# Altium schematic sheet Breathalyzer.SchDoc(Breathalyzer) (continued)

## Library data of the parts
C1-C3 C7-C9 = 08056C106KAT2A: CAP CER 10UF 6.3V X7R 0805; Manufacturer: Kyocera AVX; Tolerance: 10%; Width: 0.049inch; Voltage Rating (DC): 6.3V; Voltage Rating: 6.3V; Voltage: 6.3V; Thickness: 0.055inch; Termination: SMD/SMT; Supplier Part Number 1: 478-5322-6-ND; Supplier 1: Digi-Key; Stock 1: 0; REACH SVHC: No SVHC; Radiation Hardening: No; Pricing 1: 1=0.83, 10=0.614, 100=0.4209, 500=0.33322, 1000=0.2806 (USD); Packaging: Tape and Reel; Package Quantity: 1; Number of Pins: 2; Mount: PCB,Surface Mount; Min Operating Temperature: -55°C; Max Operating Temperature: 125°C; Manufacturer Part Number: 08056C106KAT2A; Length: 2.01mm; Lead Free: Lead Free; Height: 1.52mm; Dielectric: X7R; Depth: 1.25mm; Contact Plating: Matte,Tin; ComponentLink7URL: http://datasheets.avx.com/X7RDielectric.pdf; ComponentLink7Description: http://datasheets.avx.com/X7RDielectric.pdf; ComponentLink6URL: http://catalogs.avx.com/SurfaceMount.pdf; ComponentLink6Description: http://catalogs.avx.com/SurfaceMount.pdf; ComponentLink5URL: http://www.avx.com/docs/catalogs/cx7r.pdf; ComponentLink5Description: http://www.avx.com/docs/catalogs/cx7r.pdf; ComponentLink4URL: https://www.verical.com/server-webapp/html/getDatasheet?partID=149687; ComponentLink4Description: https://www.verical.com/server-webapp/html/getDatasheet?partID=149687; ComponentLink3URL: http://www.mouser.com/ds/2/40/X7RDielectric-777024.pdf; ComponentLink3Description: http://www.mouser.com/ds/2/40/X7RDielectric-777024.pdf; ComponentLink2URL: http://avx.com/docs/Catalogs/cx7r.pdf; ComponentLink2Description: http://avx.com/docs/Catalogs/cx7r.pdf; ComponentLink1URL: http://www.farnell.com/datasheets/2095836.pdf; ComponentLink1Description: http://www.farnell.com/datasheets/2095836.pdf; Component Kind: Standard; Case Code (Metric): 2012; Case Code (Imperial): 0805; Case/Package: 0805; Capacitance: 10uF; Library Name: Breathalyzer.SchLib
C4 C10 C14 C16 C20 C21 = GRM188R71E104KA01D: CAP CER 0.1UF 25V X7R 0603; Manufacturer: Murata; Tolerance: 10%; Material: Ceramic; Capacitance: 100nF; Width: 0.031inch; Weight: 7.1E-05oz; Voltage Rating: 25V; Voltage: 25V; Thickness: 0.035inch; Termination: SMD/SMT; Supplier Part Number 1: 490-1524-6-ND; Supplier 1: Digi-Key; Stock 1: 4000; REACH SVHC: Unknown; Radiation Hardening: No; Pricing 1: 1=0.13 (USD); Packaging: Tape and Reel; Package Quantity: 1; Number of Pins: 2; Mount: Surface Mount; Min Operating Temperature: -55°C; Max Operating Temperature: 125°C; Manufacturer Part Number: GRM188R71E104KA01D; Length: 1.6mm; Lead Free: Lead Free; Height: 0.8mm; Dielectric: X7R; Depth: 0.8mm; ComponentLink6URL: https://produktinfo.conrad.com/datenblaetter/450000-474999/451555-da-01-en-VS_KONDENSATOR_0603.pdf; ComponentLink6Description: https://produktinfo.conrad.com/datenblaetter/450000-474999/451555-da-01-en-VS_KONDENSATOR_0603.pdf; ComponentLink5URL: https://www.verical.com/server-webapp/html/getDatasheet?partID=44549; ComponentLink5Description: https://www.verical.com/server-webapp/html/getDatasheet?partID=44549; ComponentLink4URL: http://www.jameco.com/Jameco/Products/ProdDS/1857495.pdf; ComponentLink4Description: http://www.jameco.com/Jameco/Products/ProdDS/1857495.pdf; ComponentLink3URL: http://www.farnell.com/datasheets/1747454.pdf; ComponentLink3Description: http://www.farnell.com/datasheets/1747454.pdf; ComponentLink2URL: http://psearch.en.murata.com/capacitor/product/GRM188R71E103KA01%23.pdf; ComponentLink2Description: http://psearch.en.murata.com/capacitor/product/GRM188R71E103KA01%23.pdf; ComponentLink1URL: https://www.murata.com/~/media/webrenewal/support/library/catalog/products/capacitor/mlcc/c02e.ashx?la=en-us; ComponentLink1Description: https://www.murata.com/~/media/webrenewal/support/library/catalog/products/capacitor/mlcc/c02e.ashx?la=en-us; Component Kind: Standard; Case Code (Metric): 1608; Case Code (Imperial): 0603; Case/Package: 0603; Library Name: Breathalyzer.SchLib
  C4 C10 C20 C21: Voltage Rating (DC): 25V
C5 C11 C23 = GRM188R61A105KA61J: Multilayer Ceramic Capacitors MLCC - SMD/SMT 0603 1uF 10volts X5R 10%; Manufacturer: Murata; Voltage Rating (DC): 10V; Width: 0.031inch; Voltage Rating: 10V; Thickness: 0.035inch; Termination: SMD/SMT; Supplier Part Number 1: 490-6408-1-ND; Supplier 1: Digi-Key; Stock 1: 100000; REACH SVHC: No SVHC; Radiation Hardening: No; Packaging: Tape and Reel; Package Quantity: 1; Mount: Surface Mount; Min Operating Temperature: -55°C; Max Operating Temperature: 85°C; Manufacturer Part Number: GRM188R61A105KA61J; Length: 1.6mm; Height: 0.8mm; Dielectric: X5R; Depth: 0.8mm; ComponentLink1URL: https://www.murata.com/~/media/webrenewal/support/library/catalog/products/capacitor/mlcc/c02e.ashx?la=en-us; ComponentLink1Description: https://www.murata.com/~/media/webrenewal/support/library/catalog/products/capacitor/mlcc/c02e.ashx?la=en-us; Component Kind: Standard; Case Code (Metric): 1608; Case Code (Imperial): 0603; Case/Package: 0603; Library Name: Breathalyzer.SchLib
  C5 C11: Tolerance: 10%
C6 = CC0805KKX7R8BB474: CAP CER 0.47UF 25V X7R 0805; Manufacturer: Yageo; Tolerance: 10%; Insulation Resistance: 10GR; Dissipation Factor: 5%; Capacitance: 470nF; Width: 0.049inch; Voltage Rating (DC): 25V; Voltage Rating: 25V; Voltage: 25V; Thickness: 0.049inch; Termination: SMD/SMT; Supplier Part Number 1: 311-1364-6-ND; Supplier 1: Digi-Key; Stock 1: 0; REACH SVHC: No SVHC; Radiation Hardening: No; Pricing 1: 1=0.29, 10=0.197, 100=0.0997, 500=0.06824, 1000=0.06037 (USD); Packaging: Tape and Reel; Package Quantity: 3000; Number of Pins: 2; Mount: Surface Mount; Min Operating Temperature: -55°C; Max Operating Temperature: 125°C; Manufacturer Part Number: CC0805KKX7R8BB474; Length: 2mm; Lead Free: Lead Free; Height: 1.25mm; Halogen Free: Halogen Free; Dielectric: X7R; Depth: 1.25mm; Composition: Ceramic; ComponentLink5URL: http://www.mouser.com/ds/2/447/Yageo_UPY-GP_NP0_16V-to-50V_9-358835.pdf; ComponentLink5Description: http://www.mouser.com/ds/2/447/Yageo_UPY-GP_NP0_16V-to-50V_9-358835.pdf; ComponentLink4URL: https://www.verical.com/server-webapp/html/getDatasheet?partID=315216; ComponentLink4Description: https://www.verical.com/server-webapp/html/getDatasheet?partID=315216; ComponentLink3URL: http://www.yageo.com/documents/recent/UPY-GPHC_X7R_6.3V-to-50V_18.pdf; ComponentLink3Description: http://www.yageo.com/documents/recent/UPY-GPHC_X7R_6.3V-to-50V_18.pdf; ComponentLink2URL: http://www.farnell.com/datasheets/1489800.pdf; ComponentLink2Description: http://www.farnell.com/datasheets/1489800.pdf; ComponentLink1URL: http://documents.rs-components.com/techlib://itc_doclist_GB.htm?stockno=6963572; ComponentLink1Description: http://documents.rs-components.com/techlib://itc_doclist_GB.htm?stockno=6963572; Component Kind: Standard; Case Code (Metric): 2012; Case Code (Imperial): 0805; Case/Package: 0805; Library Name: Breathalyzer.SchLib
C12 C22 = GRM31CR60J107ME39K: Multilayer Ceramic Capacitors MLCC - SMD/SMT 1206 100uF 6.3volt X5R +/-20%; Manufacturer: Murata; Tolerance: 20%; Width: 0.063inch; Voltage Rating (DC): 6.3V; Voltage Rating: 6.3V; Voltage: 6.3V; Thickness: 0.071inch; Termination: SMD/SMT; Supplier Part Number 1: GRM31CR60J107ME39K-ND; Supplier 1: Digi-Key; Stock 1: 0; REACH SVHC: No SVHC; Radiation Hardening: No; Packaging: Tape and Reel; Package Quantity: 1; Mount: Surface Mount; Min Operating Temperature: -55°C; Max Operating Temperature: 85°C; Manufacturer Part Number: GRM31CR60J107ME39K; Length: 3.2mm; Lead Free: Lead Free; Height: 1.6mm; Dielectric: X5R; Depth: 1.6mm; ComponentLink2URL: https://www.murata.com/~/media/webrenewal/support/library/catalog/products/capacitor/mlcc/c02e.ashx?la=en-us; ComponentLink2Description: https://www.murata.com/~/media/webrenewal/support/library/catalog/products/capacitor/mlcc/c02e.ashx?la=en-us; ComponentLink1URL: http://www.mouser.com/ds/2/281/GRM31A7U2H471JW31-01-1064911.pdf; ComponentLink1Description: http://www.mouser.com/ds/2/281/GRM31A7U2H471JW31-01-1064911.pdf; Component Kind: Standard; Case Code (Metric): 3216; Case Code (Imperial): 1206; Case/Package: 1206; Library Name: Breathalyzer.SchLib
C13 C15 = TMK316BJ106ML-T: CAP CER 10UF 25V X5R 1206; Manufacturer: Taiyo Yuden; Tolerance: 10%; Width: 1.6mm; Voltage Rating (DC): 25V; Voltage Rating: 25V; Voltage: 25V; Thickness: 0.071inch; Termination: SMD/SMT; Supplier Part Number 1: 587-2259-6-ND; Supplier 1: Digi-Key; Stock 1: 0; REACH SVHC: Unknown; Radiation Hardening: No; Pricing 1: 1=0.34, 10=0.236, 100=0.1339, 500=0.09454, 1000=0.08271 (USD); Packaging: Tape and Reel; Package Quantity: 1; Number of Pins: 2; Mount: Surface Mount; Min Operating Temperature: -55°C; Max Operating Temperature: 85°C; Manufacturer Part Number: TMK316BJ106ML-T; Length: 3.2mm; Lead Free: Lead Free; Height: 1.6mm; Halogen Free: Halogen Free; Dielectric: X5R; Depth: 1.6mm; Contact Plating: Nickel; Composition: Ceramic; ComponentLink3URL: http://www.farnell.com/datasheets/746258.pdf; ComponentLink3Description: http://www.farnell.com/datasheets/746258.pdf; ComponentLink2URL: http://www.farnell.com/datasheets/1481447.pdf; ComponentLink2Description: http://www.farnell.com/datasheets/1481447.pdf; ComponentLink1URL: https://www.yuden.co.jp/productdata/catalog/mlcc01_e.pdf; ComponentLink1Description: https://www.yuden.co.jp/productdata/catalog/mlcc01_e.pdf; Component Kind: Standard; Case Code (Metric): 3216; Case Code (Imperial): 1206; Case/Package: 1206; Library Name: Breathalyzer.SchLib
C17-C19 C24 = UMK107SD102KA-T: CAP CER 1000PF 50V 0603; Manufacturer: Taiyo Yuden; Tolerance: 10%; Dielectric Material: Ceramic; Width: 0.8mm; Voltage Rating: 50V; Voltage: 50V; Thickness: 0.035inch; Termination: SMD/SMT; Supplier Part Number 1: 587-1070-6-ND; Supplier 1: Digi-Key; Stock 1: 4000; Radiation Hardening: No; Pricing 1: 1=0.36, 10=0.252, 100=0.1509, 500=0.1132, 1000=0.09559 (USD); Packaging: Tape and Reel; Package Quantity: 1; Number of Pins: 2; Mount: Surface Mount; Min Operating Temperature: -55°C; Max Operating Temperature: 125°C; Manufacturer Part Number: UMK107SD102KA-T; Length: 1.6mm; Lead Free: Lead Free; Height: 0.8mm; Halogen Free: Halogen Free; Depth: 0.8mm; ComponentLink3URL: http://ds.yuden.co.jp/TYCOMPAS/ut/detail.do?productNo=UMK107SD102KA-T&fileName=UMK107SD102KA-T_SS&mode=specSheetDownload; ComponentLink3Description: http://ds.yuden.co.jp/TYCOMPAS/ut/detail.do?productNo=UMK107SD102KA-T&fileName=UMK107SD102KA-T_SS&mode=specSheetDownload; ComponentLink2URL: http://documents.rs-components.com/techlib://itc_doclist_GB.htm?stockno=7587377; ComponentLink2Description: http://documents.rs-components.com/techlib://itc_doclist_GB.htm?stockno=7587377; ComponentLink1URL: http://www.yuden.co.jp/productdata/catalog/en/mlcc07_e.pdf; ComponentLink1Description: http://www.yuden.co.jp/productdata/catalog/en/mlcc07_e.pdf; Component Kind: Standard; Case Code (Metric): 1608; Case Code (Imperial): 0603; Case/Package: 0603; Library Name: Breathalyzer.SchLib
  C24: Voltage Rating (DC): 50V; Capacitance: 1nF
D1 = LTST-C193TBKT-5A: Standard LEDs - SMD Blue 470nm 28mcd 5mA; Manufacturer: Vishay Lite-On; Viewing Angle: 130°; Reverse Voltage: 5V; Power Dissipation: 76mW; Number of LEDs: 1; Luminous Intensity: 15mcd; Lens Transparency: Clear; Lens Style: Clear,Colorless,Diffused,Rectangular; Lens Colour: Clear,Colorless; Forward Voltage: 2.8V; Forward Current: 20mA; Dominant Wavelength: 475nm; Width: 0.8mm; Test Current: 5mA; Supplier Part Number 1: 859-LTSTC193TBKT5A; Supplier 1: Mouser; Stock 1: 100531; Radiation Hardening: No; Pricing 1: 1=0.43, 10=0.23, 50=0.23, 100=0.108, 1000=0.078, 10000=0.055 (USD); Packaging: Tape and Reel; Package Quantity: 1; Number of Pins: 2; Number of Elements: 1; Mount: Surface Mount; Min Operating Temperature: -20°C; Max Operating Temperature: 80°C; Manufacturer Part Number: LTST-C193TBKT-5A; Length: 1.6mm; Lead Free: Lead Free; Illumination Colour: Blue; Height: 0.35mm; Depth: 0.8mm; ComponentLink5URL: http://www1.futureelectronics.com/doc/LITE-ON/LTST-C193TBKT-5A.pdf; ComponentLink5Description: http://www1.futureelectronics.com/doc/LITE-ON/LTST-C193TBKT-5A.pdf; ComponentLink4URL: http://uk.rs-online.com/webdocs/0dc0/0900766b80dc0554.pdf; ComponentLink4Description: http://uk.rs-online.com/webdocs/0dc0/0900766b80dc0554.pdf; ComponentLink3URL: https://www.verical.com/server-webapp/html/getDatasheet?partID=293056; ComponentLink3Description: https://www.verical.com/server-webapp/html/getDatasheet?partID=293056; ComponentLink2URL: https://media.digikey.com/pdf/Data%20Sheets/Lite-On%20PDFs/LTST-C193TBKT-5A.pdf; ComponentLink2Description: https://media.digikey.com/pdf/Data%20Sheets/Lite-On%20PDFs/LTST-C193TBKT-5A.pdf; ComponentLink1URL: http://www.mouser.com/ds/2/239/Lite-On-LTST-C193TBKT-5A-187386.pdf; ComponentLink1Description: http://www.mouser.com/ds/2/239/Lite-On-LTST-C193TBKT-5A-187386.pdf; Component Kind: Standard; Colour: Blue; Case Code (Metric): 1608; Case Code (Imperial): 0603; Case/Package: 0603; Library Name: Breathalyzer.SchLib
J1 = 1042P: Cylindrical Battery Contacts, Clips, Holders & Springs SMT Polarized Holder for 18650 Battery; Manufacturer: Keystone Electronics; Number of Cells: 1; Termination: SMD/SMT; Supplier Part Number 1: 36-1042P-ND; Supplier 1: Digi-Key; Stock 1: 3395; Pricing 1: 1=2.47, 10=2.107, 50=1.892, 100=1.806, 250=1.634, 1000=1.539, 2500=1.29, 5000=1.153 (USD); Packaging: Tray; Package Quantity: 200; Mount: PCB,Surface Mount; Min Operating Temperature: -50°C; Max Operating Temperature: 145°C; Manufacturer Part Number: 1042P; Lead Free: Lead Free; ComponentLink2URL: https://www.mouser.com/datasheet/2/215/042P-744096.pdf; ComponentLink2Description: Datasheet; ComponentLink1URL: https://www.mouser.com/ProductDetail/Keystone-Electronics/1042P?qs=sGAEpiMZZMtqO%252bWUGLBzeAoSIwG%2fyfJm2NzM8DAiwxE%3d; ComponentLink1Description: Mouser; Component Kind: Standard; Library Name: Breathalyzer.SchLib
J2 = I2C Testing: 1x4 Header for I2C Testing; Component Kind: Standard; Library Name: Breathalyzer.SchLib
J3 = 12401598E4#2A: USB - C USB 3.1, Superspeed+ Receptacle Connector 24 Position Surface Mount, Right Angle, Horizontal; Manufacturer: Amphenol Commercial; Number of Contacts: 24; Supplier Part Number 1: 523-12401598E4#2A; Supplier 1: Mouser; Stock 1: 1682; Pricing 1: 1=1.75, 10=1.58, 50=1.42, 100=1.36, 1000=0.901, 10000=0.898 (USD); Packaging: Tape and Reel; Mount: Horizontal,Surface Mount; Manufacturer Part Number: 12401598E4#2A; Gender: Receptacle; Connector Type: USB; ComponentLink1URL: https://www.amphenol-icc.com/media/wysiwyg/files/drawing/12401598xxx2a.pdf; ComponentLink1Description: Drawing; Component Kind: Standard; Library Name: Breathalyzer.SchLib
L1 L2 = VLF4012AT-2R2M1R5: FIXED IND 2.2UH 1.5A 87 MOHM SMD; Manufacturer: TDK; Tolerance: 20%; Test Frequency: 100kHz; Shielding: Shielded; DC Resistance (DCR): 87mR; Core Material: Ferrite; Width: 0.138inch; Termination: SMD/SMT; Supplier Part Number 1: 445-3233-1-ND; Supplier 1: Digi-Key; Stock 1: 94427; RMS Current (Irms): 1.5A; REACH SVHC: No SVHC; Radiation Hardening: No; Pricing 1: 1=0.83, 10=0.725, 100=0.5175, 500=0.4347 (USD); Packaging: Tape and Reel; Package Quantity: 1000; Number of Pins: 2; Mount: Surface Mount; Min Operating Temperature: -40°C; Military Standard: Not; Max Operating Temperature: 105°C; Max DC Current: 1.5A; Manufacturer Part Number: VLF4012AT-2R2M1R5; Length: 0.146inch; Lead Free: Lead Free; Inductance Tolerance: 20%; Inductance: 2.2uh; Height - Seated (Max): 0.047inch; Height: 1.2mm; Depth: 3.8mm; DC Current: 1.5A; Current Rating: 1.5A; Composition: Wirewound; ComponentLink2URL: http://www.onlinecomponents.com/productFiles/mf-TDK/e531_vlf4012a.pdf; ComponentLink2Description: http://www.onlinecomponents.com/productFiles/mf-TDK/e531_vlf4012a.pdf; ComponentLink1URL: http://www.farnell.com/datasheets/333336.pdf; ComponentLink1Description: http://www.farnell.com/datasheets/333336.pdf; Component Kind: Standard; Case/Package: Nonstandard; Library Name: Breathalyzer.SchLib
Q1 Q2 = MMSS8050-L-TP: TRANS NPN 25V 1.5A SOT-23; Manufacturer: MCC; Transition Frequency: 100MHz; Max Power Dissipation: 625mW; hFE Min: 40; Emitter Base Voltage (VEBO): 5V; Element Configuration: Single; Collector Emitter Voltage (VCEO): 500mV; Collector Base Voltage (VCBO): 40V; Supplier Part Number 1: MMSS8050-L-TPMSDKR-ND; Supplier 1: Digi-Key; Stock 1: 28424; Pricing 1: 1=0.21, 10=0.19, 25=0.1728, 100=0.1246, 250=0.07336, 500=0.0609, 1000=0.04152 (USD); Polarity: NPN; Packaging: Tape and Reel; Package Quantity: 3000; Mount: Surface Mount; Min Operating Temperature: -55°C; Max Operating Temperature: 150°C; Max Collector Current: 1.5A; Max Breakdown Voltage: 25V; Manufacturer Part Number: MMSS8050-L-TP; Lead Free: Lead Free; Gain Bandwidth Product: 100MHz; ComponentLink2URL: http://www.mouser.com/ds/2/258/MMSS8050(SOT-23)-276387.pdf; ComponentLink2Description: http://www.mouser.com/ds/2/258/MMSS8050(SOT-23)-276387.pdf; ComponentLink1URL: http://www.mccsemi.com/up_pdf/MMSS8050(SOT-23).pdf; ComponentLink1Description: http://www.mccsemi.com/up_pdf/MMSS8050(SOT-23).pdf; Component Kind: Standard; Collector Emitter Saturation Voltage: 500mV; Collector Emitter Breakdown Voltage: 25V; Case/Package: TO-236-3; Library Name: Breathalyzer.SchLib
Q3 Q4 = DMP2045U-7: P-Channel MOSFET 045R at VGS=-4.5V ID = -4.3A; Manufacturer: Diodes; Supplier Part Number 1: 621-DMP2045U-7; Supplier 1: Mouser; Stock 1: 0; Pricing 1: 1=0.45, 10=0.289, 50=0.289, 100=0.124, 1000=0.095, 10000=0.064 (USD); Manufacturer Part Number: DMP2045U-7; ComponentLink1URL: https://www.diodes.com/assets/Datasheets/DMP2045U.pdf; ComponentLink1Description: Datasheet; Component Kind: Standard; Library Name: Breathalyzer.SchLib
R1 R3 R5 R6 R14-R19 R26 = RC0805FR-0710KL: RES SMD 10K OHM 1% 1/8W 0805; Manufacturer: Yageo; Voltage Rating: 150V; Temperature Coefficient: 100ppm/°C; Resistance: 10kR; Power Rating: 125mW; Width: 0.049inch; Termination: SMD/SMT; Supplier Part Number 1: 311-10.0KCRDKR-ND; Supplier 1: Digi-Key; Stock 1: 50767607; REACH SVHC: Unknown; Pricing 1: 1=0.1, 10=0.046, 100=0.0186, 1000=0.00835, 2500=0.00725 (USD); Packaging: Tape and Reel; Package Quantity: 1; Number of Terminations: 2; Min Operating Temperature: -55°C; Military Standard: Not; Max Power Dissipation: 125mW; Max Operating Temperature: 155°C; Manufacturer Part Number: RC0805FR-0710KL; Length: 2mm; Lead Free: Lead Free; Height: 0.024inch; Features: Moisture Resistant; Depth: 1.25mm; Composition: Thick Film; ComponentLink3URL: http://www.yageo.ru/pdf/RC0805.pdf; ComponentLink3Description: http://www.yageo.ru/pdf/RC0805.pdf; ComponentLink2URL: http://www.farnell.com/datasheets/444164.pdf; ComponentLink2Description: http://www.farnell.com/datasheets/444164.pdf; ComponentLink1URL: http://www.yageo.com/documents/recent/PYu-RC_Group_51_RoHS_L_9.pdf; ComponentLink1Description: http://www.yageo.com/documents/recent/PYu-RC_Group_51_RoHS_L_9.pdf; Component Kind: Standard; Case Code (Metric): 2012; Case Code (Imperial): 0805; Case/Package: 0805; Library Name: Breathalyzer.SchLib
  R1 R15 R16: Tolerance: 1%
R2 R10 = RC0805JR-07100RL: RES, THICK FILM, 100R, 5%, 0.125W, 0805; Manufacturer: Yageo; Voltage Rating: 150V; Temperature Coefficient: 100ppm/°C; Power Rating: 125mW; Tolerance: 5%; Termination: SMD/SMT; Supplier Part Number 1: 603-RC0805JR-07100RL; Supplier 1: Mouser; Stock 1: 497207; Resistance: 100R; REACH SVHC: Unknown; Radiation Hardening: No; Pricing 1: 1=0.11, 10=0.026, 50=0.026, 100=0.009, 1000=0.007, 10000=0.004 (USD); Packaging: Tape and Reel; Min Operating Temperature: -55°C; Max Operating Temperature: 155°C; Manufacturer Part Number: RC0805JR-07100RL; Lead Free: Lead Free; Contact Plating: Lead,Matte,Tin; Composition: Thick Film; ComponentLink4URL: http://www.yageo.ru/pdf/RC0805.pdf; ComponentLink4Description: http://www.yageo.ru/pdf/RC0805.pdf; ComponentLink3URL: http://www.farnell.com/datasheets/442941.pdf; ComponentLink3Description: http://www.farnell.com/datasheets/442941.pdf; ComponentLink2URL: http://www.farnell.com/datasheets/2167052.pdf; ComponentLink2Description: http://www.farnell.com/datasheets/2167052.pdf; ComponentLink1URL: http://www.farnell.com/datasheets/444164.pdf; ComponentLink1Description: http://www.farnell.com/datasheets/444164.pdf; Component Kind: Standard; Case Code (Metric): 2012; Case Code (Imperial): 0805; Case/Package: 0805; Library Name: Breathalyzer.SchLib
R4 R23 = RC0603FR-07100KL: YAGEO         RC0603FR-07100KL             RES, THICK FILM, 100K, 1%, 0.1W, 0603; Working Voltage: 75V; Voltage Rating (DC): 50V; Temperature Coefficient: 100ppm/°C; Resistance: 100kR; Power Rating: 100mW; Width: 0.8mm; Voltage Rating: 50V; Tolerance: 1%; Termination: SMD/SMT; Supplier Part Number 1: 603-RC0603FR-07100KL; Supplier 1: Mouser; Stock 1: 667937; REACH SVHC: No SVHC; Pricing 1: 1=0.1, 10=0.018, 50=0.018, 100=0.006, 1000=0.005, 10000=0.003 (USD); Packaging: Tape and Reel; Number of Terminations: 2; Number of Pins: 2; Min Operating Temperature: -55°C; Military Standard: Not; Max Operating Temperature: 155°C; Manufacturer Part Number: RC0603FR-07100KL; Manufacturer: Yageo; Length: 1.6mm; Lead Free: Lead Free; Height: 0.45mm; Depth: 0.8mm; Composition: Thick Film; ComponentLink5URL: http://www.farnell.com/datasheets/443971.pdf; ComponentLink5Description: http://www.farnell.com/datasheets/443971.pdf; ComponentLink4URL: http://documents.rs-components.com/techlib://itc_doclist_GB.htm?stockno=5048940; ComponentLink4Description: http://documents.rs-components.com/techlib://itc_doclist_GB.htm?stockno=5048940; ComponentLink3URL: http://www.farnell.com/datasheets/1738695.pdf; ComponentLink3Description: http://www.farnell.com/datasheets/1738695.pdf; ComponentLink2URL: http://www.farnell.com/datasheets/1868787.pdf; ComponentLink2Description: http://www.farnell.com/datasheets/1868787.pdf; ComponentLink1URL: http://www.farnell.com/datasheets/2167052.pdf; ComponentLink1Description: http://www.farnell.com/datasheets/2167052.pdf; Component Kind: Standard; Case Code (Metric): 1608; Case Code (Imperial): 0603; Case/Package: 0603; Library Name: Breathalyzer.SchLib
R7 = CRCW080518K0FKEA: RES SMD 18K OHM 1% 1/8W 0805; Manufacturer: Vishay; Working Voltage: 150V; Temperature Coefficient: 100ppm/°C; Resistance: 18kR; Power Rating: 125mW; Width: 0.049inch; Weight: 0.000194oz; Voltage Rating (DC): 150V; Voltage Rating: 150V; Tolerance: 1%; Termination: SMD/SMT; Supplier Part Number 1: 541-18.0KCDKR-ND; Supplier 1: Digi-Key; Stock 1: 0; REACH SVHC: Unknown; Radiation Hardening: No; Pricing 1: 1=0.1, 10=0.069, 100=0.028, 1000=0.01256, 2500=0.0109 (USD); Packaging: Tape and Reel; Package Quantity: 10; Number of Terminations: 2; Number of Pins: 2; Min Operating Temperature: -55°C; Military Standard: Not; Max Power Dissipation: 125mW; Max Operating Temperature: 155°C; Manufacturer Part Number: CRCW080518K0FKEA; Length: 2mm; Lead Free: Lead Free; Height: 0.45mm; Features: Automotive AEC-Q200; Depth: 1.25mm; Contact Plating: Tin; Composition: Thick Film; ComponentLink6URL: http://www.mouser.com/ds/2/427/dcrcwe3-109170.pdf; ComponentLink6Description: http://www.mouser.com/ds/2/427/dcrcwe3-109170.pdf; ComponentLink5URL: http://uk.rs-online.com/webdocs/0d98/0900766b80d98b26.pdf; ComponentLink5Description: http://uk.rs-online.com/webdocs/0d98/0900766b80d98b26.pdf; ComponentLink4URL: http://www.alliedelec.com/m/d/0c934d6939ccefa68dbe0b96d821c8cf.pdf; ComponentLink4Description: http://www.alliedelec.com/m/d/0c934d6939ccefa68dbe0b96d821c8cf.pdf; ComponentLink3URL: http://www.farnell.com/datasheets/2046716.pdf; ComponentLink3Description: http://www.farnell.com/datasheets/2046716.pdf; ComponentLink2URL: https://www.distrelec.biz/Web/Downloads/a_/en/flD-CRCW-e3_data_en.pdf?mime=application%2Fpdf; ComponentLink2Description: https://www.distrelec.biz/Web/Downloads/a_/en/flD-CRCW-e3_data_en.pdf?mime=application%2Fpdf; ComponentLink1URL: http://www.vishay.com/docs/20035/dcrcwe3.pdf; ComponentLink1Description: http://www.vishay.com/docs/20035/dcrcwe3.pdf; Component Kind: Standard; Case Code (Metric): 2012; Case Code (Imperial): 0805; Case/Package: 0805; Library Name: Breathalyzer.SchLib
R8 = CRCW06031K10FKEA: RES SMD 1.1K OHM 1% 1/10W 0603; Manufacturer: Vishay; Voltage Rating (DC): 75V; Temperature Coefficient: 100ppm/°C; Resistance: 1.1kR; Power Rating: 100mW; Width: 0.033inch; Weight: 7.1E-05oz; Voltage Rating: 75V; Tolerance: 1%; Termination: SMD/SMT; Supplier Part Number 1: 541-1.10KHDKR-ND; Supplier 1: Digi-Key; Stock 1: 0; REACH SVHC: Unknown; Radiation Hardening: No; Pricing 1: 1=0.1, 10=0.042, 100=0.017, 1000=0.00764, 2500=0.00663 (USD); Packaging: Tape and Reel; Package Quantity: 10; Number of Terminations: 2; Number of Pins: 2; Min Operating Temperature: -55°C; Military Standard: Not; Max Power Dissipation: 100mW; Max Operating Temperature: 155°C; Manufacturer Part Number: CRCW06031K10FKEA; Length: 1.55mm; Lead Free: Lead Free; Height: 0.02inch; Features: Automotive AEC-Q200; Depth: 0.85mm; Contact Plating: Tin; Composition: Thick Film; ComponentLink6URL: http://www.mouser.com/ds/2/427/dcrcwe3-109170.pdf; ComponentLink6Description: http://www.mouser.com/ds/2/427/dcrcwe3-109170.pdf; ComponentLink5URL: https://www.alliedelec.com/m/d/0c934d6939ccefa68dbe0b96d821c8cf.pdf; ComponentLink5Description: https://www.alliedelec.com/m/d/0c934d6939ccefa68dbe0b96d821c8cf.pdf; ComponentLink4URL: https://www.distrelec.biz/Web/Downloads/a_/en/flD-CRCW-e3_data_en.pdf?mime=application%2Fpdf; ComponentLink4Description: https://www.distrelec.biz/Web/Downloads/a_/en/flD-CRCW-e3_data_en.pdf?mime=application%2Fpdf; ComponentLink3URL: http://www.vishay.com/docs/20035/dcrcwe3.pdf; ComponentLink3Description: http://www.vishay.com/docs/20035/dcrcwe3.pdf; ComponentLink2URL: https://www.verical.com/server-webapp/html/getDatasheet?partID=26323; ComponentLink2Description: https://www.verical.com/server-webapp/html/getDatasheet?partID=26323; ComponentLink1URL: http://www.farnell.com/datasheets/2045673.pdf; ComponentLink1Description: http://www.farnell.com/datasheets/2045673.pdf; Component Kind: Standard; Case Code (Metric): 1608; Case Code (Imperial): 0603; Case/Package: 0603; Library Name: Breathalyzer.SchLib
R9 = ERA6AEB6980V: RESISTOR, 0805, 698R, 0.1%, 0.125W; Manufacturer: Panasonic; Voltage Rating (DC): 100V; Temperature Coefficient: 25ppm/°C; Power Rating: 125mW; Width: 1.25mm; Voltage Rating: 100V; Tolerance: 0.1%; Termination: SMD/SMT; Supplier Part Number 1: 667-ERA-6AEB6980V; Supplier 1: Mouser; Stock 1: 9539; Radiation Hardening: No; Pricing 1: 1=0.63, 10=0.249, 50=0.249, 100=0.149, 1000=0.076, 10000=0.039 (USD); Packaging: Tape and Reel; Package Quantity: 1; Number of Terminations: 2; Number of Pins: 2; Mount: Surface Mount; Min Operating Temperature: -55°C; Military Standard: Not; Max Power Dissipation: 125mW; Max Operating Temperature: 155°C; Manufacturer Part Number: ERA6AEB6980V; Length: 2mm; Lead Free: Lead Free; Height: 0.5mm; Features: Automotive AEC-Q200; Depth: 1.25mm; Contact Plating: Tin; Composition: Metal Film,Thin Film; ComponentLink4URL: http://www.produktinfo.conrad.com/datenblaetter/1100000-1199999/001181233-da-01-en-WIDERSTAND_ERA_0805_698R_0_1__5000ST.pdf; ComponentLink4Description: http://www.produktinfo.conrad.com/datenblaetter/1100000-1199999/001181233-da-01-en-WIDERSTAND_ERA_0805_698R_0_1__5000ST.pdf; ComponentLink3URL: http://uk.rs-online.com/webdocs/0eb1/0900766b80eb1d8c.pdf; ComponentLink3Description: http://uk.rs-online.com/webdocs/0eb1/0900766b80eb1d8c.pdf; ComponentLink2URL: http://www.farnell.com/datasheets/485442.pdf; ComponentLink2Description: http://www.farnell.com/datasheets/485442.pdf; ComponentLink1URL: http://industrial.panasonic.com/www-data/pdf/AOA0000/AOA0000CE26.pdf; ComponentLink1Description: http://industrial.panasonic.com/www-data/pdf/AOA0000/AOA0000CE26.pdf; Component Kind: Standard; Case Code (Metric): 2012; Case Code (Imperial): 0805; Case/Package: 0805; Library Name: Breathalyzer.SchLib
R11 R20 R21 = RC0805FR-07470RL: RES SMD 470 OHM 1% 1/8W 0805; Manufacturer: Yageo; Voltage Rating (DC): 150V; Temperature Coefficient: 100ppm/°C; Power Rating: 125mW; Width: 1.25mm; Voltage Rating: 150V; Termination: SMD/SMT; Supplier Part Number 1: 311-470CRDKR-ND; Supplier 1: Digi-Key; Stock 1: 2436062; REACH SVHC: Unknown; Pricing 1: 1=0.1, 10=0.046, 100=0.0186, 1000=0.00835, 2500=0.00725 (USD); Packaging: Tape and Reel; Package Quantity: 1; Number of Terminations: 2; Number of Pins: 2; Min Operating Temperature: -55°C; Military Standard: Not; Max Power Dissipation: 125mW; Max Operating Temperature: 155°C; Manufacturer Part Number: RC0805FR-07470RL; Length: 2mm; Lead Pitch: 0.4mm; Lead Free: Lead Free; Height: 0.5mm; Features: Moisture Resistant; Depth: 1.25mm; Composition: Thick Film; ComponentLink4URL: http://www.yageo.ru/pdf/RC0805.pdf; ComponentLink4Description: http://www.yageo.ru/pdf/RC0805.pdf; ComponentLink3URL: http://documents.rs-components.com/techlib://itc_doclist_GB.htm?stockno=6182546; ComponentLink3Description: http://documents.rs-components.com/techlib://itc_doclist_GB.htm?stockno=6182546; ComponentLink2URL: http://www.farnell.com/datasheets/444164.pdf; ComponentLink2Description: http://www.farnell.com/datasheets/444164.pdf; ComponentLink1URL: http://www.yageo.com/documents/recent/PYu-RC_Group_51_RoHS_L_9.pdf; ComponentLink1Description: http://www.yageo.com/documents/recent/PYu-RC_Group_51_RoHS_L_9.pdf; Component Kind: Standard; Case Code (Metric): 2012; Case Code (Imperial): 0805; Case/Package: 0805; Library Name: Breathalyzer.SchLib
R12 R13 = RC0603FR-070RL: Thick Film Resistors - SMD 0.0ohm 1%; Manufacturer: Yageo; Working Voltage: 75V; Voltage Rating (DC): 50V; Temperature Coefficient: 200ppm/°C; Resistance: 0mR; Power Rating: 100mW; Current Rating: 1A; Width: 0.031inch; Voltage Rating: 50V; Termination: SMD/SMT; Supplier Part Number 1: 311-0.0HRCT-ND; Supplier 1: Mouser; Stock 1: 0; REACH SVHC: No SVHC; Radiation Hardening: No; Packaging: Tape and Reel; Package Quantity: 5000; Number of Terminations: 2; Number of Pins: 2; Min Operating Temperature: -55°C; Military Standard: Not; Max Power Dissipation: 100mW; Max Operating Temperature: 155°C; Manufacturer Part Number: RC0603FR-070RL; Length: 1.6mm; Lead Free: Lead Free; Height: 0.45mm; Features: Moisture Resistant; Depth: 0.8mm; Contact Plating: Matte,Tin; Composition: Thick Film; ComponentLink4URL: http://documents.rs-components.com/techlib://itc_doclist_GB.htm?stockno=5048827; ComponentLink4Description: http://documents.rs-components.com/techlib://itc_doclist_GB.htm?stockno=5048827; ComponentLink3URL: http://www.yageo.com/documents/recent/PYu-RC_Group_51_RoHS_L_9.pdf; ComponentLink3Description: http://www.yageo.com/documents/recent/PYu-RC_Group_51_RoHS_L_9.pdf; ComponentLink2URL: http://www.farnell.com/datasheets/443971.pdf; ComponentLink2Description: http://www.farnell.com/datasheets/443971.pdf; ComponentLink1URL: http://www.farnell.com/datasheets/1868787.pdf; ComponentLink1Description: http://www.farnell.com/datasheets/1868787.pdf; Component Kind: Standard; Case Code (Metric): 1608; Case Code (Imperial): 0603; Case/Package: 0603; Library Name: Breathalyzer.SchLib
R22 = CRCW0603910KFKEA: RES SMD 910K OHM 1% 1/10W 0603; Manufacturer: Vishay; Voltage Rating (DC): 75V; Temperature Coefficient: 100ppm/°C; Resistance: 910kR; Power Rating: 100mW; Width: 0.033inch; Weight: 7.1E-05oz; Voltage Rating: 75V; Tolerance: 1%; Termination: SMD/SMT; Supplier Part Number 1: 541-910KHTR-ND; Supplier 1: Digi-Key; Stock 1: 0; REACH SVHC: Unknown; Radiation Hardening: No; Pricing 1: 5000=0.00497, 10000=0.00432, 25000=0.00405 (USD); Packaging: Tape and Reel; Package Quantity: 10; Number of Terminations: 2; Number of Pins: 2; Min Operating Temperature: -55°C; Military Standard: Not; Max Power Dissipation: 100mW; Max Operating Temperature: 155°C; Manufacturer Part Number: CRCW0603910KFKEA; Length: 1.55mm; Lead Free: Lead Free; Height: 0.02inch; Features: Automotive AEC-Q200; Depth: 0.85mm; Contact Plating: Tin; Composition: Thick Film; ComponentLink5URL: http://www.farnell.com/datasheets/1697337.pdf; ComponentLink5Description: http://www.farnell.com/datasheets/1697337.pdf; ComponentLink4URL: http://www.farnell.com/datasheets/2046716.pdf; ComponentLink4Description: http://www.farnell.com/datasheets/2046716.pdf; ComponentLink3URL: http://www.farnell.com/datasheets/2111203.pdf; ComponentLink3Description: http://www.farnell.com/datasheets/2111203.pdf; ComponentLink2URL: http://www.mouser.com/ds/2/427/dcrcwe3-109170.pdf; ComponentLink2Description: http://www.mouser.com/ds/2/427/dcrcwe3-109170.pdf; ComponentLink1URL: http://www.vishay.com/docs/20035/dcrcwe3.pdf; ComponentLink1Description: http://www.vishay.com/docs/20035/dcrcwe3.pdf; Component Kind: Standard; Case Code (Metric): 1608; Case Code (Imperial): 0603; Case/Package: 0603; Library Name: Breathalyzer.SchLib
R24 = ERJ-8BWFR010V: Current Sense Resistor, 0.01 ohm, 1206 [3216 Metric], 1 W,  1%, ERJ8B Series; Manufacturer: Panasonic; Temperature Coefficient: 200ppm/°C; Resistance: 10mR; Width: 1.6mm; Termination: SMD/SMT; Supplier Part Number 1: P.010AUDKR-ND; Supplier 1: Digi-Key; Stock 1: 131309; REACH SVHC: No SVHC; Radiation Hardening: No; Pricing 1: 1=0.74, 10=0.618, 100=0.4148, 1000=0.2427, 2500=0.23475 (USD); Packaging: Tape and Reel; Package Quantity: 1; Number of Terminations: 2; Mount: Surface Mount; Min Operating Temperature: -55°C; Military Standard: Not; Max Power Dissipation: 1W; Max Operating Temperature: 155°C; Manufacturer Part Number: ERJ-8BWFR010V; Length: 3.2mm; Lead Free: Lead Free; Height: 0.65mm; Features: Automotive AEC-Q200; Depth: 1.6mm; Contact Plating: Tin; Composition: Low-Ohm-Resistor,Thick Film; ComponentLink5URL: http://www.farnell.com/datasheets/39793.pdf; ComponentLink5Description: http://www.farnell.com/datasheets/39793.pdf; ComponentLink4URL: https://industrial.panasonic.com/cdbs/www-data/pdf/RDN0000/AOA0000C313.pdf; ComponentLink4Description: https://industrial.panasonic.com/cdbs/www-data/pdf/RDN0000/AOA0000C313.pdf; ComponentLink3URL: https://www.distrelec.biz/Web/Downloads/_t/ds/ERJ_eng_tds.pdf?mime=application%2Fpdf; ComponentLink3Description: https://www.distrelec.biz/Web/Downloads/_t/ds/ERJ_eng_tds.pdf?mime=application%2Fpdf; ComponentLink2URL: http://industrial.panasonic.com/www-data/pdf/AOA0000/AOA0000CE3.pdf; ComponentLink2Description: http://industrial.panasonic.com/www-data/pdf/AOA0000/AOA0000CE3.pdf; ComponentLink1URL: http://uk.rs-online.com/webdocs/13b8/0900766b813b869e.pdf; ComponentLink1Description: http://uk.rs-online.com/webdocs/13b8/0900766b813b869e.pdf; Component Kind: Standard; Case Code (Metric): 3216; Case Code (Imperial): 1206; Case/Package: 1206; Library Name: Breathalyzer.SchLib
R25 = CRCW080582R0FKEA: RES SMD 82 OHM 1% 1/8W 0805; Manufacturer: Vishay; Voltage Rating (DC): 150V; Temperature Coefficient: 100ppm/°C; Power Rating: 125mW; Width: 0.049inch; Weight: 0.000194oz; Voltage Rating: 150V; Termination: SMD/SMT; Supplier Part Number 1: 541-82.0CDKR-ND; Supplier 1: Digi-Key; Stock 1: 0; REACH SVHC: Unknown; Radiation Hardening: No; Pricing 1: 1=0.1, 10=0.069, 100=0.028, 1000=0.01256, 2500=0.0109 (USD); Packaging: Tape and Reel; Package Quantity: 10; Number of Terminations: 2; Number of Pins: 2; Min Operating Temperature: -55°C; Military Standard: Not; Max Power Dissipation: 125mW; Max Operating Temperature: 155°C; Manufacturer Part Number: CRCW080582R0FKEA; Length: 2mm; Lead Free: Lead Free; Height: 0.45mm; Features: Automotive AEC-Q200; Depth: 1.25mm; Contact Plating: Tin; Composition: Thick Film; ComponentLink8URL: http://uk.rs-online.com/webdocs/0d98/0900766b80d98b26.pdf; ComponentLink8Description: http://uk.rs-online.com/webdocs/0d98/0900766b80d98b26.pdf; ComponentLink7URL: http://www.mouser.com/ds/2/427/dcrcwe3-109170.pdf; ComponentLink7Description: http://www.mouser.com/ds/2/427/dcrcwe3-109170.pdf; ComponentLink6URL: https://www.distrelec.biz/Web/Downloads/a_/en/flD-CRCW-e3_data_en.pdf?mime=application%2Fpdf; ComponentLink6Description: https://www.distrelec.biz/Web/Downloads/a_/en/flD-CRCW-e3_data_en.pdf?mime=application%2Fpdf; ComponentLink5URL: http://www.farnell.com/datasheets/2046716.pdf; ComponentLink5Description: http://www.farnell.com/datasheets/2046716.pdf; ComponentLink4URL: http://www.farnell.com/datasheets/2111203.pdf; ComponentLink4Description: http://www.farnell.com/datasheets/2111203.pdf; ComponentLink3URL: http://www.farnell.com/datasheets/1697337.pdf; ComponentLink3Description: http://www.farnell.com/datasheets/1697337.pdf; ComponentLink2URL: https://www.alliedelec.com/m/d/0c934d6939ccefa68dbe0b96d821c8cf.pdf; ComponentLink2Description: https://www.alliedelec.com/m/d/0c934d6939ccefa68dbe0b96d821c8cf.pdf; ComponentLink1URL: http://www.vishay.com/docs/20035/dcrcwe3.pdf; ComponentLink1Description: http://www.vishay.com/docs/20035/dcrcwe3.pdf; Component Kind: Standard; Case Code (Metric): 2012; Case Code (Imperial): 0805; Case/Package: 0805; Library Name: Breathalyzer.SchLib
SW1 = PCM12SMTR: C & K COMPONENTS         PCM12SMTR             Slide Switch, SPDT, On-On, SMD, PCM Series, 300 mA; Manufacturer: ITT C&K; Voltage Rating (DC): 6V; Switch Travel: 1.5mm; Mechanical Life: 10000Cycles; Max Current Rating: 300mA; Insulation Resistance: 100mR; Contact Resistance: 70mR; Actuator Type: Standard; Actuator Material: Nylon,Polyamide; Width: 6.7mm; Voltage Rating (AC): 6V; Throw Configuration: SPDT; Termination: Gull Wing; Switch Function: On-On; Supplier Part Number 1: 611-PCM12SMTR; Supplier 1: Mouser; Stock 1: 22229; REACH SVHC: No SVHC; Pricing 1: 1=0.87, 10=0.83, 50=0.762, 100=0.726, 1000=0.581, 10000=0.528 (USD); Packaging: Tape and Reel; Package Quantity: 1; Orientation: Right Angle; Mount: Surface Mount; Min Operating Temperature: -20°C; Max Voltage Rating (DC): 6V; Max Operating Temperature: 85°C; Manufacturer Part Number: PCM12SMTR; Length: 7.7mm; Lead Free: Lead Free; Depth: 5mm; Current Rating: 300mA; Contact Plating: Silver; Contact Material: Copper,Copper Alloy,Silver; Contact Current Rating: 300mA; ComponentLink4URL: http://www.farnell.com/datasheets/1789487.pdf; ComponentLink4Description: http://www.farnell.com/datasheets/1789487.pdf; ComponentLink3URL: http://www1.futureelectronics.com/doc/C%20&%20K%20COMPONENTS/PCM12SMTR.pdf; ComponentLink3Description: http://www1.futureelectronics.com/doc/C%20&%20K%20COMPONENTS/PCM12SMTR.pdf; ComponentLink2URL: https://products.avnet.com/shop/en/asia/switches-and-relays/switches/slide-switch/www.ckswitches.com/media/1424/pcm.pdf; ComponentLink2Description: https://products.avnet.com/shop/en/asia/switches-and-relays/switches/slide-switch/www.ckswitches.com/media/1424/pcm.pdf; ComponentLink1URL: https://www.ckswitches.com/media/1424/pcm.pdf; ComponentLink1Description: https://www.ckswitches.com/media/1424/pcm.pdf; Component Kind: Standard; Actuator Length: 1.5mm; Library Name: Breathalyzer.SchLib
SW2 SW3 = EVPAWCD4A: Tactile Switch; Manufacturer: Panasonic; Supplier Part Number 1: P123439CT-ND; Supplier 1: Digikey; Stock 1: 19414; Pricing 1: 1=0.3, 10=0.289, 25=0.2788, 50=0.2686, 100=0.2582, 250=0.23756, 500=0.20658, 1000=0.17559, 5000=0.14719 (USD); Manufacturer Part Number: EVPAWCD4A; ComponentLink1URL: http://datasheet.octopart.com/EVPAWCD4A-Panasonic-datasheet-96186218.pdf; ComponentLink1Description: http://datasheet.octopart.com/EVPAWCD4A-Panasonic-datasheet-96186218.pdf; Component Kind: Standard; Library Name: Breathalyzer.SchLib
SW4 = PTS645SM43SMTR: Switch Tact 6mm 100gf h=4.3mm; MF: C&K; SnapEDA_Link: https://www.snapeda.com/parts/PTS645SM43SMTR/C%26K/view-part/?ref=snap; Price: None; Package: None; MP: PTS645SM43SMTR; Component Kind: Standard; Availability: Unavailable; Library Name: Breathalyzer.SchLib
U1 = BQ24075RGTT: TEXAS INSTRUMENTS   BQ24075RGTT   IC, BATTERY CHARGER,LI-ION, 1.5A, QFN-16; Output Voltage: 4.2V; Number of Cells: 1; Min Supply Voltage: 4.35V; Min Output Voltage: 5.4V; Min Input Voltage: 4.3V; Max Supply Voltage: 6.4V; Max Output Voltage: 5.6V; Max Output Current: 4.5A; Max Input Voltage: 26V; Width: 3.15mm; Weight: 0.000808oz; Termination: SMD/SMT; Supplier Part Number 1: 595-BQ24075RGTT; Supplier 1: Mouser; Stock 1: 2131; REACH SVHC: No SVHC; Radiation Hardening: No; Pricing 1: 1=2.59, 10=2.2, 50=2.2, 100=1.76, 1000=1.28, 10000=1.15 (USD); Packaging: Tape and Reel; Package Quantity: 1; Output Current: 1.5A; Number of Pins: 16; Min Operating Temperature: -40°C; Max Operating Temperature: 85°C; Max Charge Current: 1.5A; Manufacturer Part Number: BQ24075RGTT; Manufacturer: Texas Instruments; Length: 3.15mm; Lead Free: Lead Free; Height: 0.95mm; Contact Plating: Gold; ComponentLink7URL: http://datasheet.iiic.cc/texas_instruments/BQ24075RGTT.pdf; ComponentLink7Description: http://datasheet.iiic.cc/texas_instruments/BQ24075RGTT.pdf; ComponentLink6URL: http://www.ti.com/general/docs/lit/getliterature.tsp?genericPartNumber=BQ24079&&fileType=pdf; ComponentLink6Description: http://www.ti.com/general/docs/lit/getliterature.tsp?genericPartNumber=BQ24079&&fileType=pdf; ComponentLink5URL: http://www.ti.com/lit/ds/symlink/bq24079.pdf; ComponentLink5Description: http://www.ti.com/lit/ds/symlink/bq24079.pdf; ComponentLink4URL: http://www.farnell.com/datasheets/2001589.pdf; ComponentLink4Description: http://www.farnell.com/datasheets/2001589.pdf; ComponentLink3URL: http://www.farnell.com/datasheets/1885912.pdf; ComponentLink3Description: http://www.farnell.com/datasheets/1885912.pdf; ComponentLink2URL: http://www.ti.com/lit/gpn/bq24075; ComponentLink2Description: http://www.ti.com/lit/gpn/bq24075; ComponentLink1URL: https://www.verical.com/server-webapp/html/getDatasheet?partID=313264; ComponentLink1Description: https://www.verical.com/server-webapp/html/getDatasheet?partID=313264; Component Kind: Standard; Case/Package: QFN; Library Name: Breathalyzer.SchLib
U2 = MiCS-5524: 10-500ppm Ethanol Sensor; Manufacturer: Amphenol SGX Sensortech; Supply Voltage Min: 4.9V; Supply Voltage Max: 5.1V; Sensing resistance in air (R_0): 100 to 1500R; Relative humidity range: 5-95%RH; Methane range: >1000ppm; Max sensitive layer power dissipation: 8mW; Max heater power dissipation: 88mW; Hydrogen range: 1-1000ppm; Heating voltage: 2.4V; Heating resistance at nominal power: 74R typ, 66R min, 82R max; Heating power: 76mW typ, 71mW min, 81mW max; Current Rating: 32mA; Ammonia range: 1-500ppm; Supplier Part Number 1: 523-MICS-5524; Supplier 1: Mouser; Storage temperature range: -40 to 120C; Series: MICS; Mounting Style: SMD; Minimum Operating Temp: -30C; Maximum Operating Temp: +85C; Ethanol range: 10-500ppm; ComponentLink3URL: https://www.mouser.com/datasheet/2/18/1084_Datasheet-MiCS-5524-rev-8-1144838.pdf; ComponentLink3Description: Datasheet; ComponentLink2URL: https://sgx.cdistore.com/Products/Detail/MICS5524-SGX-Sensortech/333420/; ComponentLink2Description: Manufacturer; ComponentLink1URL: https://learn.adafruit.com/adafruit-mics5524-gas-sensor-breakout/overview; ComponentLink1Description: Hook-up Guide; Component Kind: Standard; Category: Air Quality Sensors; Carbon monoxide range: 1-1000ppm; Library Name: Breathalyzer.SchLib
U3 = TPS63002DRCR: IC REG BUCK BOOST 5V 1.6A 10SON; Manufacturer: Texas Instruments; Topology: Buck-Boost; Synchronous Rectifier: Yes; Switching Frequency: 1.5MHz; Quiescent Current: 30uA; Output Type: Fixed; Output Current: 1.2A; Operating Supply Voltage: 5.5V; Number of Outputs: 1; Min Input Voltage: 1.8V; Efficiency: 96%; Weight: 0.000921oz; Supplier Part Number 1: 595-TPS63002DRCR; Supplier 1: Mouser; Stock 1: 3675; REACH SVHC: No SVHC; Radiation Hardening: No; Pricing 1: 1=2.2, 10=1.87, 50=1.87, 100=1.5, 1000=1.09, 10000=0.973 (USD); Packaging: Tape and Reel; Package Quantity: 1; Output Voltage: 5V; Number of Pins: 10; Nominal Output Voltage: 5V; Min Output Voltage: 5V; Min Operating Temperature: -40°C; Max Output Voltage: 5V; Max Output Current: 1.2A; Max Operating Temperature: 85°C; Max Input Voltage: 5.5V; Manufacturer Part Number: TPS63002DRCR; Lead Free: Lead Free; Contact Plating: Gold; ComponentLink4URL: http://www.ti.com/general/docs/lit/getliterature.tsp?genericPartNumber=TPS63002&&fileType=pdf; ComponentLink4Description: http://www.ti.com/general/docs/lit/getliterature.tsp?genericPartNumber=TPS63002&&fileType=pdf; ComponentLink3URL: http://focus.ti.com/lit/ds/symlink/tps63001.pdf; ComponentLink3Description: http://focus.ti.com/lit/ds/symlink/tps63001.pdf; ComponentLink2URL: http://www.ti.com/lit/ds/symlink/tps63001.pdf; ComponentLink2Description: http://www.ti.com/lit/ds/symlink/tps63001.pdf; ComponentLink1URL: http://www.farnell.com/datasheets/1854797.pdf; ComponentLink1Description: http://www.farnell.com/datasheets/1854797.pdf; Component Kind: Standard; Library Name: Breathalyzer.SchLib
U4 = TPD8S300RUKR: SURGE SUPP 8VC 2-CIRCUIT 20WQFN; Manufacturer: Texas Instruments; Interface: USB; Capacitance: 1.5pF; Supplier Part Number 1: 595-TPD8S300RUKR; Supplier 1: Mouser; Stock 1: 24813; Pricing 1: 1=2.56, 10=2.17, 50=2.17, 100=1.74, 1000=1.26, 10000=1.13 (USD); Min Operating Temperature: -40°C; Max Operating Temperature: 125°C; Manufacturer Part Number: TPD8S300RUKR; Lead Free: Lead Free; ComponentLink1URL: http://www.ti.com/lit/ds/symlink/tpd8s300.pdf; ComponentLink1Description: Datasheet; Component Kind: Standard; Library Name: Breathalyzer.SchLib
U5 = TPS63001DRCT: Conv DC-DC Single Non-Inv/Inv/Step Up/Step Down 1.8V to 5.5V 10-Pin VSON EP T/R; Manufacturer: Texas Instruments; Topology: Buck-Boost; Synchronous Rectifier: Yes; Switching Frequency: 1.5MHz; Quiescent Current: 30uA; Output Voltage: 3.3V; Output Type: Fixed; Output Current: 1.2A; Output Configuration: Positive; Number of Outputs: 1; Efficiency: 96%; Width: 3mm; Weight: 0.000921oz; Termination: SMD/SMT; Supplier Part Number 1: 595-TPS63001DRCT; Supplier 1: Mouser; Stock 1: 4204; REACH SVHC: No SVHC; Radiation Hardening: No; Pricing 1: 1=2.53, 10=2.15, 50=2.15, 100=1.73, 1000=1.25, 10000=1.12 (USD); Packaging: Tape and Reel; Package Quantity: 250; Number of Pins: 10; Nominal Output Voltage: 3.3V; Min Supply Voltage: 1.8V; Min Output Voltage: 3.3V; Min Operating Temperature: -40°C; Min Input Voltage: 1.8V; Max Supply Voltage: 5.5V; Max Output Voltage: 3.3V; Max Output Current: 1.2A; Max Operating Temperature: 85°C; Max Input Voltage: 5.5V; Manufacturer Part Number: TPS63001DRCT; Length: 3mm; Lead Free: Lead Free; Height: 0.9mm; Frequency: 1.5MHz; Contact Plating: Gold; ComponentLink4URL: https://www.verical.com/server-webapp/html/getDatasheet?partID=188363; ComponentLink4Description: https://www.verical.com/server-webapp/html/getDatasheet?partID=188363; ComponentLink3URL: http://www.ti.com/general/docs/lit/getliterature.tsp?genericPartNumber=TPS63002&&fileType=pdf; ComponentLink3Description: http://www.ti.com/general/docs/lit/getliterature.tsp?genericPartNumber=TPS63002&&fileType=pdf; ComponentLink2URL: http://www.farnell.com/datasheets/1764456.pdf; ComponentLink2Description: http://www.farnell.com/datasheets/1764456.pdf; ComponentLink1URL: http://www.ti.com/lit/ds/symlink/tps63001.pdf; ComponentLink1Description: http://www.ti.com/lit/ds/symlink/tps63001.pdf; Component Kind: Standard; Library Name: Breathalyzer.SchLib
U6 = BQ27441DRZR-G1A: IC BATT FUEL GAUGE LI-ION 12SON; Manufacturer: Texas Instruments; Output Voltage: 600mV; Output Current: 500uA; Operating Supply Voltage: 4.5V; Number of Cells: 1; Interface: I2C; Capacity: 8000mAh; Supplier Part Number 1: 595-BQ27441DRZR-G1A; Supplier 1: Mouser; Stock 1: 12994; Pricing 1: 1=2.54, 10=2.16, 50=2.16, 100=1.73, 1000=1.25, 10000=1.13 (USD); Packaging: Tape and Reel; Package Quantity: 3000; Number of Pins: 12; Mount: Surface Mount; Min Operating Temperature: -40°C; Max Operating Temperature: 85°C; Manufacturer Part Number: BQ27441DRZR-G1A; Lead Free: Lead Free; Contact Plating: Gold; ComponentLink1URL: http://www.ti.com/lit/ds/symlink/bq27441-g1.pdf; ComponentLink1Description: Datasheet; Component Kind: Standard; Case/Package: QFN; Library Name: Breathalyzer.SchLib
U7 = CP2102N-A01-GQFN28: SILICON LABS         CP2102N-A01-GQFN28             Interface Bridges, USB to UART, 3 V, 3.6 V, QFN, 28 Pins, -40 C                          New; Min Supply Voltage: 3V; Max Supply Voltage: 3.6V; Supplier Part Number 1: 336-3694-ND; Supplier 1: Digi-Key; Stock 1: 15372; REACH SVHC: Unknown; Pricing 1: 1=1.4, 25=1.3516, 100=1.2995, 1000=1.22501 (USD); Number of Pins: 28; Min Operating Temperature: -40°C; Max Operating Temperature: 85°C; Manufacturer Part Number: CP2102N-A01-GQFN28; Manufacturer: Silicon Labs; Component Kind: Standard; Case/Package: QFN; Library Name: Breathalyzer.SchLib
U8 = ESP32-WROOM-32D: ESP32 Module; Manufacturer: Espressif Systems; Supplier Part Number 1: 356-ESP32WROOM-32D; Supplier 1: Mouser; Stock 1: 1150; Pricing 1: 1=3.8, 10=3.8, 50=3.8, 100=3.8, 1000=3.8, 10000=3.8 (USD); Manufacturer Part Number: ESP32-WROOM-32D; ComponentLink1URL: https://www.mouser.com/datasheet/2/891/esp32-wroom-32d_esp32-wroom-32u_datasheet_en-1365844.pdf; ComponentLink1Description: Datasheet; Component Kind: Standard; Library Name: Breathalyzer.SchLib
U9 = TUSB322IRWBR: USB Interface, Host / Peripheral Controller, USB 1.0, 1.1, 4.5 V, 5.5 V, X2QFN, 12 Pins; Manufacturer: Texas Instruments; USB Standard: USB 1.0; Number of Ports: 1; Min Supply Voltage: 4.5V; Max Supply Voltage: 5.5V; Supplier Part Number 1: 595-TUSB322IRWBR; Supplier 1: Mouser; Stock 1: 2739; REACH SVHC: No SVHC; Pricing 1: 1=2.34, 10=1.99, 50=1.99, 100=1.59, 1000=1.15, 10000=1.04 (USD); Packaging: Cut Tape; Number of Pins: 12; Mount: Surface Mount; Min Operating Temperature: -40°C; Max Operating Temperature: 85°C; Manufacturer Part Number: TUSB322IRWBR; Lead Free: Lead Free; Component Kind: Standard; Library Name: Breathalyzer.SchLib
